# Altium schematic sheet Sheet1.SchDoc(Sheet1)
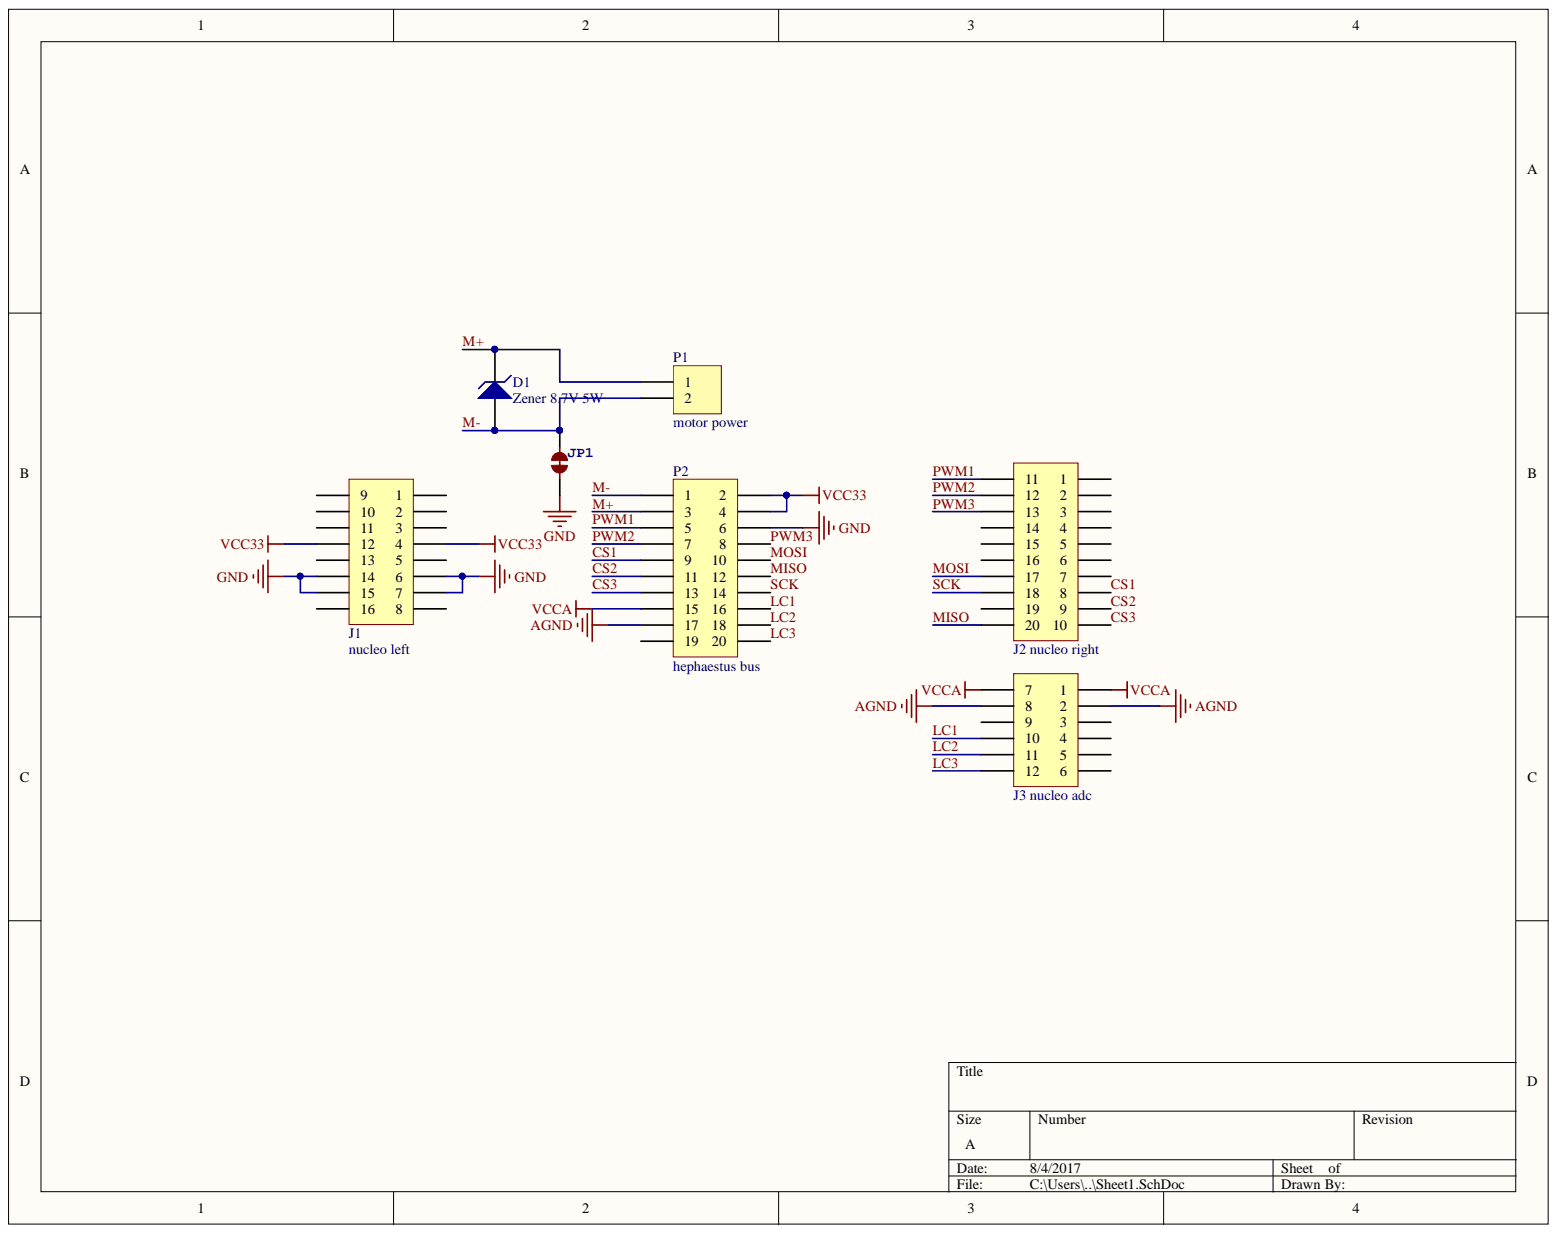
[diagram p0: Sheet1.SchDoc]

## Parts
D1  Zener 8.7V 5W  [DIODE-0.7]
J1  nucleo left  [61301621121]
J2  nucleo right  [61302021121]
J3  nucleo adc  [61301221121]
JP1  JUMPER-PAD-2-NC_BY_TRACENO_SILK  [PAD-JUMPER-2-NC_BY_TRACE_NO_SILK]  (value not drawn)
P1  motor power  [691213710002]
P2  hephaestus bus  [612020235121]

## Nets
AGND: J3.2 J3.8 P2.17
GND: J1.6 J1.7 J1.14 J1.15 JP1.1 P2.6
VCC33: J1.4 J1.12 P2.2 P2.4
VCCA: J3.1 J3.7 P2.15
CS1: J2.8 P2.9
CS2: J2.9 P2.11
CS3: J2.10 P2.13
LC1: J3.10 P2.16
LC2: J3.11 P2.18
LC3: J3.12 P2.20
M+: D1.2 P1.1 P2.3
M-: D1.1 JP1.2 P1.2 P2.1
MISO: J2.20 P2.12
MOSI: J2.17 P2.10
PWM1: J2.11 P2.5
PWM2: J2.12 P2.7
PWM3: J2.13 P2.8
SCK: J2.18 P2.14

Not connected: J1 1 2 3 5 8 9 10 11 13 16; J2 1 2 3 4 5 6 7 14 15 16 19; J3 3 4 5 6 9; P2 19

## Library data of the parts
D1 = D Zener: 1N5345BRLG; Simulation: ZENER; Signal Integrity: D Zener; Published: 8-Jun-2000; PackageReference: DIODE-0.7; PackageDescription: Diode, Thru-Hole; 2 Leads; 0.7 in Pin Spacing; Library Name: Miscellaneous Devices.IntLib; LatestRevisionNote: Re-released for DXP Platform.; LatestRevisionDate: 17-Jul-2002; Component Kind: Standard; Publisher: Altium Limited
J1 = 61301621121: WR-PHD 2.54 mm THT Dual Pin Header, 16p; PublishedDate: July 2016; PinNumber: 16p; PartNumber: 61301621121; Library Name: ALTIUM-PHD_2_54_THT_PIN_HEADER_6130XX11121-rev16c.IntLib; ComponentLink2URL: http://katalog.we-online.de/em/datasheet/6130xx21121.pdf; ComponentLink2Description: Datasheet; ComponentLink1URL: http://www.we-online.de; ComponentLink1Description: Manufacturer; Component Kind: Standard; Publisher: Wurth Elektronik
J2 = 61302021121: WR-PHD 2.54 mm THT Dual Pin Header, 20p; PublishedDate: July 2016; PinNumber: 20p; PartNumber: 61302021121; Library Name: ALTIUM-PHD_2_54_THT_PIN_HEADER_6130XX11121-rev16c.IntLib; ComponentLink2URL: http://katalog.we-online.de/em/datasheet/6130xx21121.pdf; ComponentLink2Description: Datasheet; ComponentLink1URL: http://www.we-online.de; ComponentLink1Description: Manufacturer; Component Kind: Standard; Publisher: Wurth Elektronik
J3 = 61301221121: WR-PHD 2.54 mm THT Dual Pin Header, 12p; PublishedDate: July 2016; PinNumber: 12p; PartNumber: 61301221121; Library Name: ALTIUM-PHD_2_54_THT_PIN_HEADER_6130XX11121-rev16c.IntLib; ComponentLink2URL: http://katalog.we-online.de/em/datasheet/6130xx21121.pdf; ComponentLink2Description: Datasheet; ComponentLink1URL: http://www.we-online.de; ComponentLink1Description: Manufacturer; Component Kind: Standard; Publisher: Wurth Elektronik
P1 = 691213710002: WR-TBL Serie 213 - 5.00 mm Horizontal Entry Modular, 2p; Pitch: 5 mm; Pin Number: 2; Published Date: March 2017; Part Number: 691213710002; Library Name: ALTIUM-TBL_5_00_2537_HORIZONTAL_ENTRY_W_RISING_CAGE_69125371000x-rev17a.IntLib; ComponentLink2URL: http://katalog.we-online.de/em/datasheet/6912137100xx.pdf; ComponentLink2Description: Datasheet; ComponentLink1URL: http://www.we-online.de; ComponentLink1Description: Manufacturer; Component Kind: Standard; Publisher: Wurth Elektronik
P2 = 612020235121: WR-BHD 2.54mm Male Box Header High Temperature; Manufacturer: Wurth Elektronik; NoPins: 20; ComponentLink2 Description: Datasheet; ComponentLink1 Description: Manufacturer; partNo: 612020235121; Library Name: ALTIUM-BHD_2_54_MALE_BOX_HEADER_6120XX21621-rev16b.IntLib; Created Date: March 2016; ComponentLink2URL: http://katalog.we-online.de/em/datasheet/6120xx235121.pdf; ComponentLink1URL: http://www.we-online.com; Component Kind: Standard; Created by: Baojun Chen
JP1 = JUMPER-PAD-2-NC_BY_TRACENO_SILK: Library Name: SparkFun-Passives.IntLib; Component Kind: Net Tie
